# Altium schematic sheet Top.SchDoc(Top)
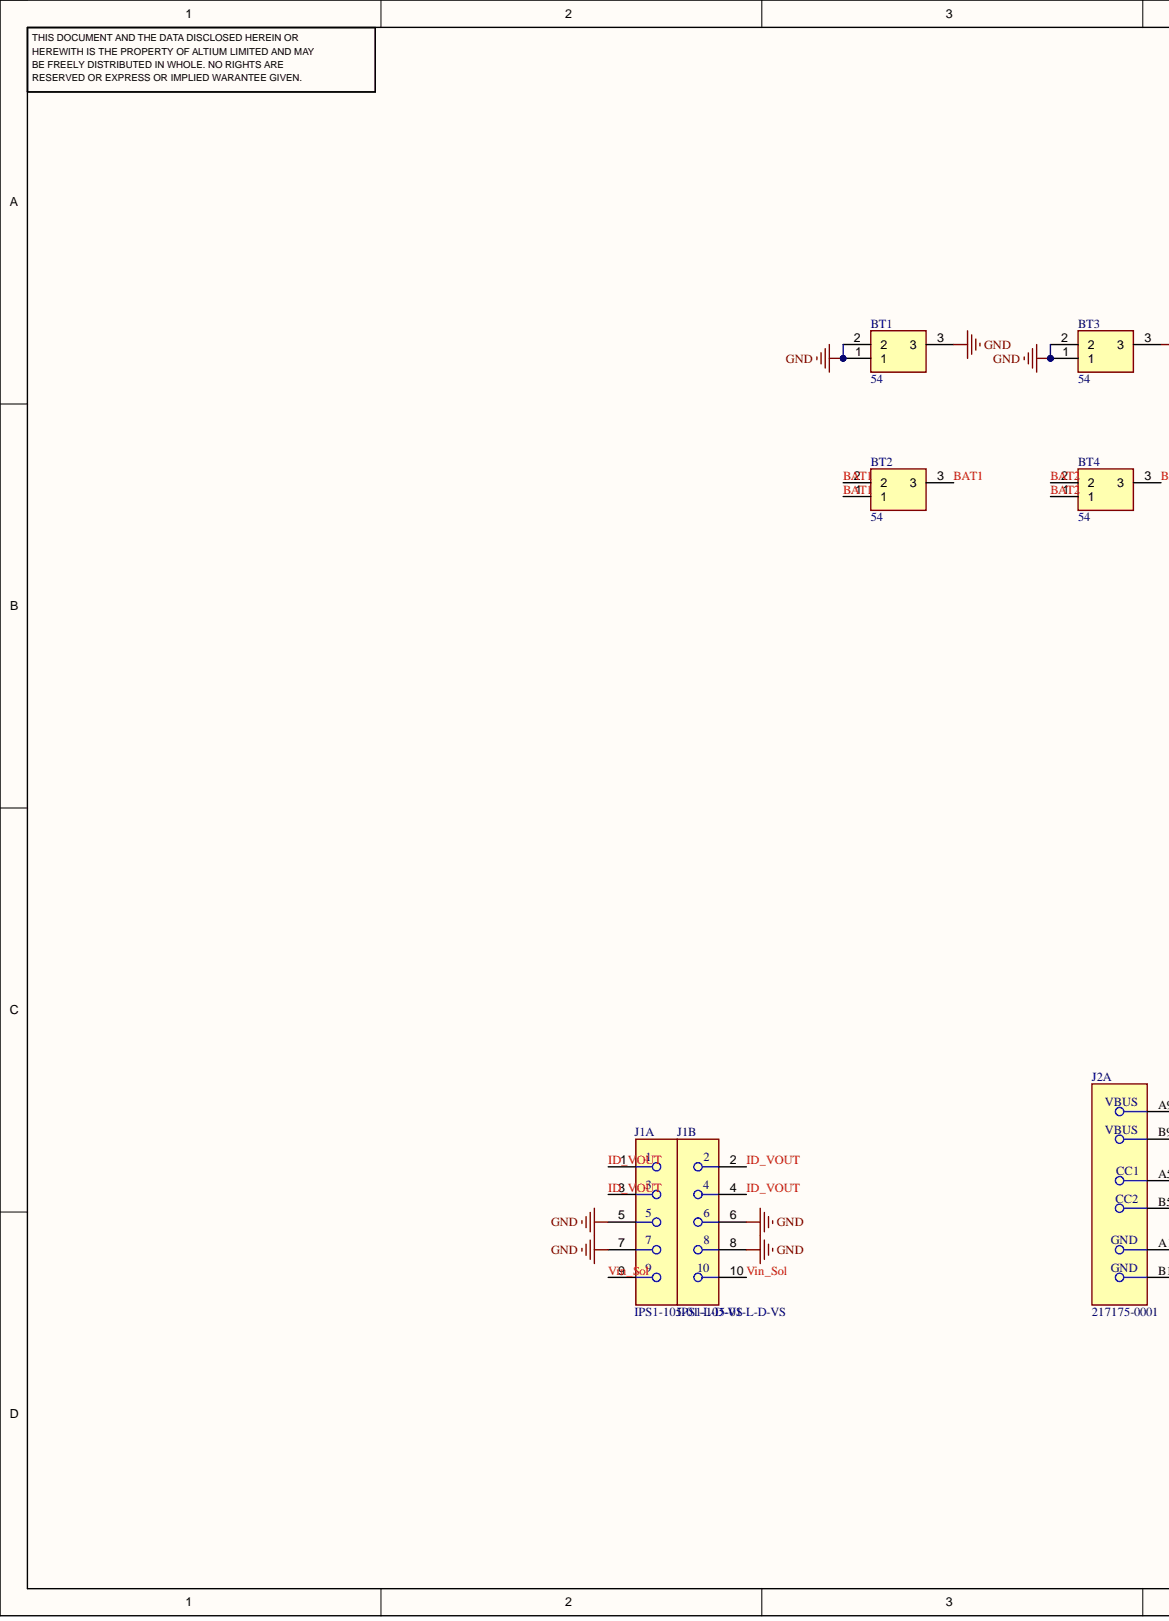
[diagram p0: Top.SchDoc, left]
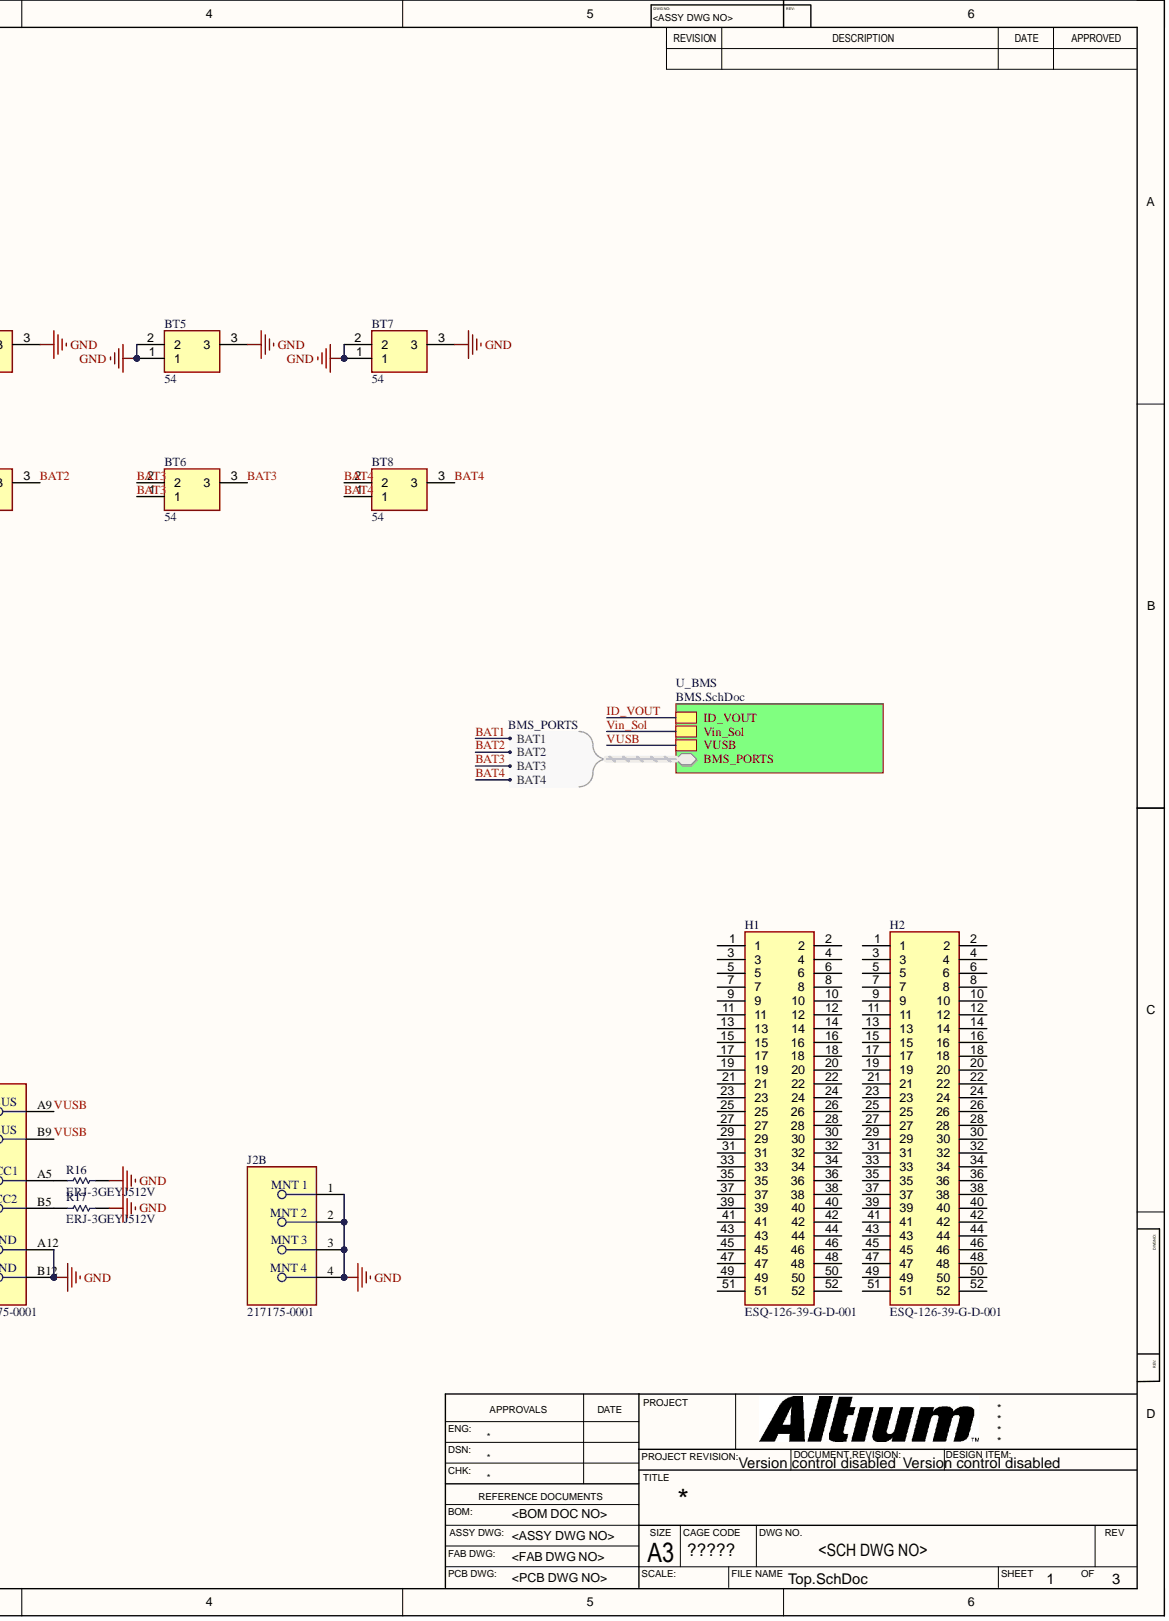
[diagram p1: Top.SchDoc, right]

## Parts
BT1  54
BT2  54
BT3  54
BT4  54
BT5  54
BT6  54
BT7  54
BT8  54
H1  ESQ-126-39-G-D-001
H2  ESQ-126-39-G-D-001
J1  IPS1-105-01-L-D-VS  (parts A,B)
J2  217175-0001  (parts A,B)
R16  ERJ-3GEYJ512V
R17  ERJ-3GEYJ512V

## Nets
GND: BT1.1 BT1.2 BT1.3 BT3.1 BT3.2 BT3.3 BT5.1 BT5.2 BT5.3 BT7.1 BT7.2 BT7.3 J1.5 J1.6 J1.7 J1.8 J2.1 J2.2 J2.3 J2.4 J2.A12 J2.B12 R16.2 R17.2
BAT1: BT2.1 BT2.2 BT2.3
BAT2: BT4.1 BT4.2 BT4.3
BAT3: BT6.1 BT6.2 BT6.3
BAT4: BT8.1 BT8.2 BT8.3
ID_VOUT: J1.1 J1.2 J1.3 J1.4
Vin_Sol: J1.9 J1.10
VUSB: J2.A9 J2.B9
NetH1_1: H1.1
NetH1_2: H1.2
NetH1_3: H1.3
NetH1_4: H1.4
NetH1_5: H1.5
NetH1_6: H1.6
NetH1_7: H1.7
NetH1_8: H1.8
NetH1_9: H1.9
NetH1_10: H1.10
NetH1_11: H1.11
NetH1_12: H1.12
NetH1_13: H1.13
NetH1_14: H1.14
NetH1_15: H1.15
NetH1_16: H1.16
NetH1_17: H1.17
NetH1_18: H1.18
NetH1_19: H1.19
NetH1_20: H1.20
NetH1_21: H1.21
NetH1_22: H1.22
NetH1_23: H1.23
NetH1_24: H1.24
NetH1_25: H1.25
NetH1_26: H1.26
NetH1_27: H1.27
NetH1_28: H1.28
NetH1_29: H1.29
NetH1_30: H1.30
NetH1_31: H1.31
NetH1_32: H1.32
NetH1_33: H1.33
NetH1_34: H1.34
NetH1_35: H1.35
NetH1_36: H1.36
NetH1_37: H1.37
NetH1_38: H1.38
NetH1_39: H1.39
NetH1_40: H1.40
NetH1_41: H1.41
NetH1_42: H1.42
NetH1_43: H1.43
NetH1_44: H1.44
NetH1_45: H1.45
NetH1_46: H1.46
NetH1_47: H1.47
NetH1_48: H1.48
NetH1_49: H1.49
NetH1_50: H1.50
NetH1_51: H1.51
NetH1_52: H1.52
NetH2_1: H2.1
NetH2_2: H2.2
NetH2_3: H2.3
NetH2_4: H2.4
NetH2_5: H2.5
NetH2_6: H2.6
NetH2_7: H2.7
NetH2_8: H2.8
NetH2_9: H2.9
NetH2_10: H2.10
NetH2_11: H2.11
NetH2_12: H2.12
NetH2_13: H2.13
NetH2_14: H2.14
NetH2_15: H2.15
NetH2_16: H2.16
NetH2_17: H2.17
NetH2_18: H2.18
NetH2_19: H2.19
NetH2_20: H2.20
NetH2_21: H2.21
NetH2_22: H2.22
NetH2_23: H2.23
NetH2_24: H2.24
NetH2_25: H2.25
NetH2_26: H2.26
NetH2_27: H2.27
NetH2_28: H2.28
NetH2_29: H2.29
NetH2_30: H2.30
NetH2_31: H2.31
NetH2_32: H2.32
NetH2_33: H2.33
NetH2_34: H2.34
NetH2_35: H2.35
NetH2_36: H2.36
NetH2_37: H2.37
NetH2_38: H2.38
NetH2_39: H2.39
NetH2_40: H2.40
NetH2_41: H2.41
NetH2_42: H2.42
NetH2_43: H2.43
NetH2_44: H2.44
NetH2_45: H2.45
NetH2_46: H2.46
NetH2_47: H2.47
NetH2_48: H2.48
NetH2_49: H2.49
NetH2_50: H2.50
NetH2_51: H2.51
NetH2_52: H2.52
NetJ2_A5: J2.A5 R16.1
NetJ2_B5: J2.B5 R17.1

## Library data of the parts
BT1-BT8 = CMP-00029-00001-1: Battery; Manufacturer_Name: Keystone Electronics; Arrow Part Number: 54; Arrow Price/Stock: https://www.arrow.com/en/products/54/keystone-electronics?utm_currency=USD&region=europe; Component Kind: Standard; Datasheet Link: http://www.keyelco.com/product-pdf.cfm?p=826; Design Item ID: 54; Height: 16.97mm; Manufacturer_Part_Number: 54; Mouser Part Number: 534-54; Mouser Price/Stock: https://www.mouser.co.uk/ProductDetail/Keystone-Electronics/54?qs=73q%2FgRcW4F3NakoJDesViw%3D%3D; Source: SamacSys.SchLib; Library Name: Hitesh Bhoyar
H1 H2 = CMP-002-00086-1: PC / 104 Connectors Elevated Socket Strip; Manufacturer_Name: SAMTEC; Arrow Part Number: ESQ-126-39-G-D-001; Arrow Price/Stock: https://www.arrow.com/en/products/esq-126-39-g-d-001/samtec?region=nac; Component Kind: Standard; Datasheet Link: http://suddendocs.samtec.com/catalog_english/esq_th.pdf; Design Item ID: ESQ-126-39-G-D-001; Height: 11.35mm; Manufacturer_Part_Number: ESQ-126-39-G-D-001; Mouser Part Number: 200-ESQ12639GD001; Mouser Price/Stock: https://www.mouser.co.uk/ProductDetail/Samtec/ESQ-126-39-G-D-001?qs=PB6%2FjmICvI2K%2FCIgL4SVbg%3D%3D; Source: SamacSys.SchLib; Library Name: Hitesh Bhoyar
J1 = CMP-02766-000002-1: CONN RCPT 10POS 0.1 GOLD SMD; Manufacturer: Samtec; Conn Gender: Female; Conn Orientation: Vertical; Is Female: yes; Number Of Contacts: 10; Pitch: 2.54mm; Standoff Height: 1.02mm; Voltage Rating: 1095V; Automotive: No; Category: Conn; Component Kind: Standard; ComponentLink2Description: Datasheet; ComponentLink2URL: http://suddendocs.samtec.com/prints/ips1-1xx-xx-xx-d-vs-xx-xx-mkt.pdf; Contact Material: Gold,Nickel; Device Class L1: Connectors; Device Class L2: Headers and Wire Housings; Device Class L3: unset; Digikey Description: CONN RCPT 10POS 0.1 GOLD SMD; Footprint Url: http://suddendocs.samtec.com/prints/ips1-vs.pdf; Height: 8.64mm; Lead Free: Yes; Library Name: Altium Content Vault; Manufacturer Part Number: IPS1-105-01-L-D-VS; Number Of Rows: 2; Octopart: https://octopart.com/ips1-105-01-l-d-vs-samtec-19544880; Package: 0; RoHS: TRUE; Temperature: -55°C to +125°C; Temperature Range High: +125°C; Temperature Range Low: -55°C
J2 = CMP-04767-000026-1: CONN RCP USB2.0 C 6+18P SMD RA; Component Kind: Standard; Library Name: Altium Content Vault
R16 R17 = CMP-2000-00803-1: Manufacturer: Panasonic; Component Kind: Standard; Library Name: Altium Content Vault; Part Number: ERJ-3GEYJ512V
